# Altium schematic sheet Power - Current_Probe_V0.2.SchDoc
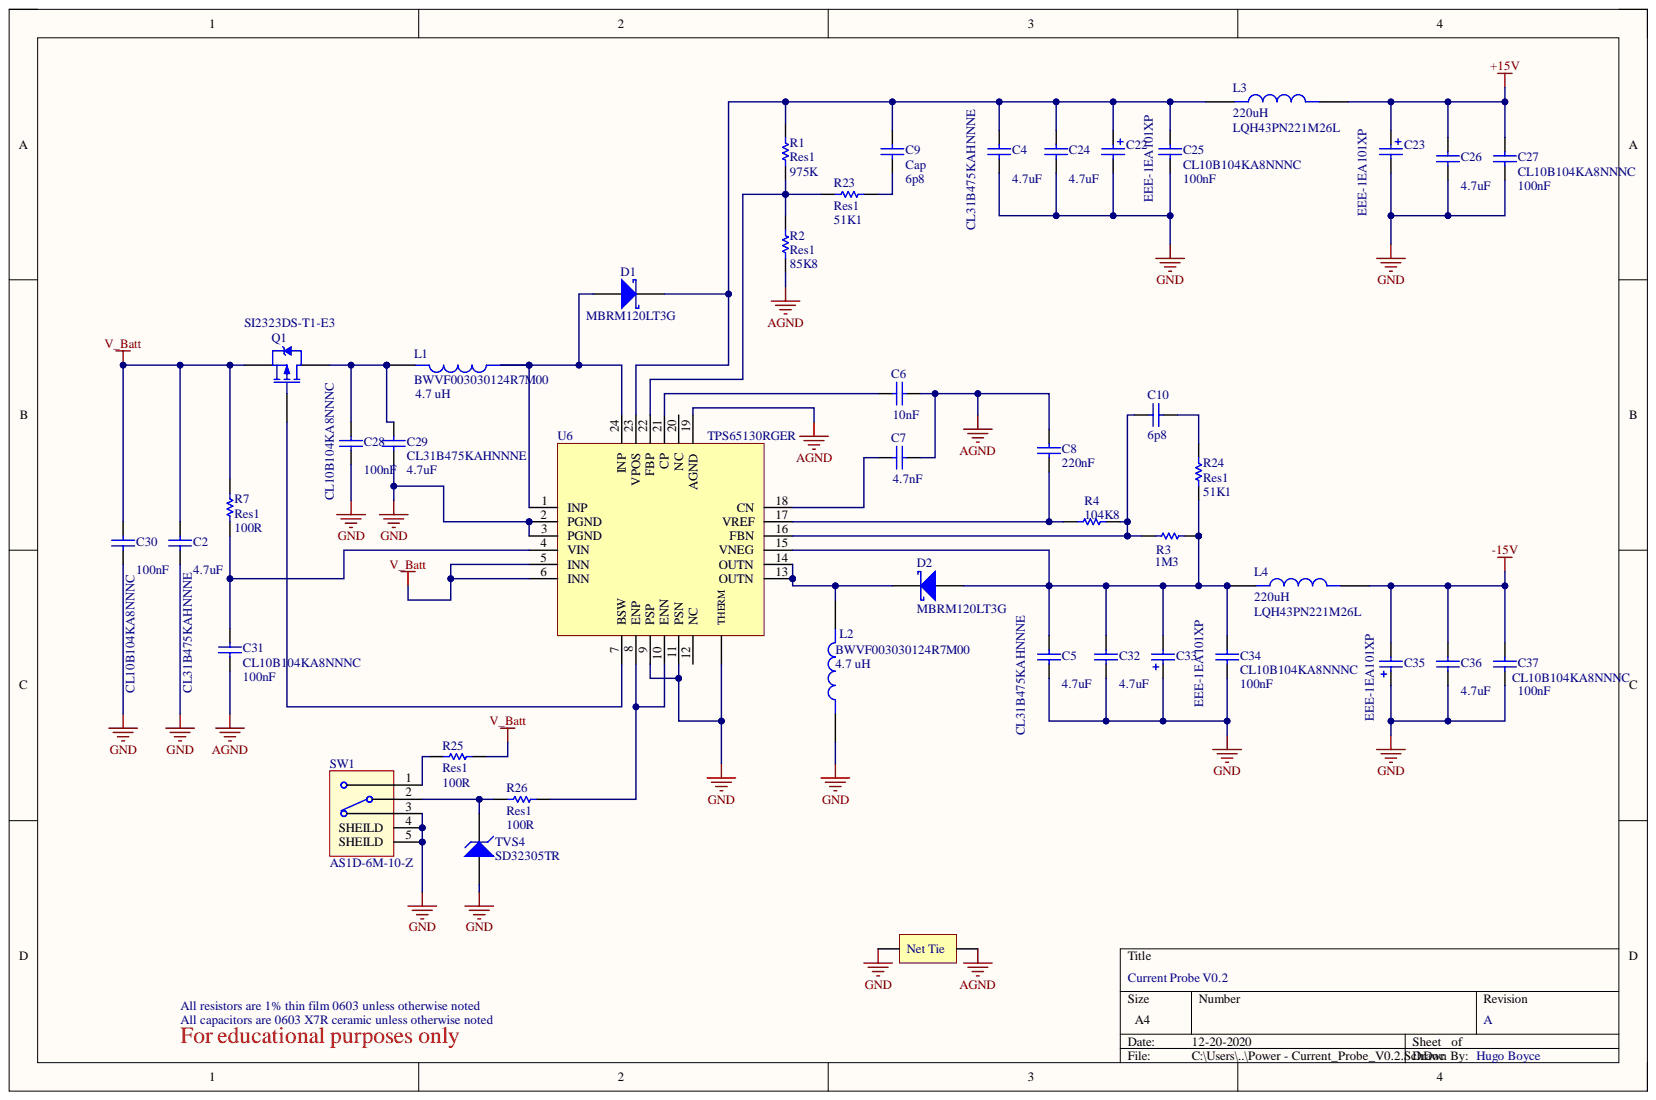
[diagram p0: Power - Current_Probe_V0.2.SchDoc]

## Parts
*  Net_Tie  [Net_Tie]
C2  CL31B475KAHNNNE  value 4.7uF  [CAPC3216N]
C4  CL31B475KAHNNNE  value 4.7uF  [CAPC3216N]
C5  CL31B475KAHNNNE  value 4.7uF  [CAPC3216N]
C6  value 10nF  [CAPC2012N]  (hidden comment: Cap)
C7  value 4.7nF  [CAPC2012N]  (hidden comment: Cap)
C8  value 220nF  [CAPC2012N]  (hidden comment: Cap)
C9  Cap  value 6p8  [CAPC2012N]
C10  value 6p8  [CAPC2012N]  (hidden comment: Cap)
C22  EEE-1EA101XP  [SMD_Electrolytic_Panasonic_Size_D8]
C23  EEE-1EA101XP  [SMD_Electrolytic_Panasonic_Size_D8]
C24  CL31B475KAHNNNE  value 4.7uF  [CAPC3216N]
C25  CL10B104KA8NNNC  value 100nF  [CAPC1608N]
C26  CL31B475KAHNNNE  value 4.7uF  [CAPC3216N]
C27  CL10B104KA8NNNC  value 100nF  [CAPC1608N]
C28  CL10B104KA8NNNC  value 100nF  [CAPC1608N]
C29  CL31B475KAHNNNE  value 4.7uF  [CAPC3216N]
C30  CL10B104KA8NNNC  value 100nF  [CAPC1608N]
C31  CL10B104KA8NNNC  value 100nF  [CAPC1608N]
C32  CL31B475KAHNNNE  value 4.7uF  [CAPC3216N]
C33  EEE-1EA101XP  [SMD_Electrolytic_Panasonic_Size_D8]
C34  CL10B104KA8NNNC  value 100nF  [CAPC1608N]
C35  EEE-1EA101XP  [SMD_Electrolytic_Panasonic_Size_D8]
C36  CL31B475KAHNNNE  value 4.7uF  [CAPC3216N]
C37  CL10B104KA8NNNC  value 100nF  [CAPC1608N]
D1  MBRM120LT3G  [DO-216AA]
D2  MBRM120LT3G  [DO-216AA]
L1  BWVF003030124R7M00  value 4.7 uH  [BWVF00303012]
L2  BWVF003030124R7M00  value 4.7 uH  [BWVF00303012]
L3  LQH43PN221M26L  value 220uH  [IND_LQH43PN221M26L]
L4  LQH43PN221M26L  value 220uH  [IND_LQH43PN221M26L]
Q1  SI2323DS-T1-E3  [SOT23-3N]
R1  Res1  value 975K  [RESC1608N]
R2  Res1  value 85K8  [RESC1608N]
R3  value 1M3  [RESC1608N]  (hidden comment: Res1)
R4  value 104K8  [RESC1608N]  (hidden comment: Res1)
R7  Res1  value 100R  [RESC1608N]
R23  Res1  value 51K1  [RESC1608N]
R24  Res1  value 51K1  [RESC1608N]
R25  Res1  value 100R  [RESC1608N]
R26  Res1  value 100R  [RESC1608N]
SW1  AS1D-6M-10-Z  [AS1D-6M-10-Z]
TVS4  SD32305TR  [SODFL260X100-2N]
U6  TPS65130RGER  [TPS65130RGER]

## Nets
+15V: C23.K C26.2 C27.2 L3.2
-15V: C35.A C36.2 C37.2 L4.2
AGND: *.2 C6.1 C7.1 C8.1 C31.1 R2.1 U6.19(AGND)
GND: *.1 C2.1 C4.1 C5.1 C22.A C23.A C24.1 C25.1 C26.1 C27.1 C28.1 C29.1 C30.1 C32.1 C33.K C34.1 C35.K C36.1 C37.1 L2.1 SW1.3 SW1.4(SHEILD) SW1.5(SHEILD) TVS4.A U6.2(PGND) U6.3(PGND) U6.9(PSP) U6.11(PSN) U6.25(THERM)
V_Batt: C2.2 C30.2 Q1.2 R7.2 R25.2 U6.5(INN) U6.6(INN)
NetC4_2: C4.2 C9.2 C22.K C24.2 C25.2 D1.1 L3.1 R1.2 U6.23(VPOS)
NetC5_2: C5.2 C32.2 C33.A C34.2 D2.2 L4.1 R3.1 R24.2 U6.15(VNEG)
NetC6_2: C6.2 U6.21(CP)
NetC7_2: C7.2 U6.18(CN)
NetC8_2: C8.2 R4.2 U6.17(VREF)
NetC9_1: C9.1 R23.1
NetC10_1: C10.1 R3.2 R4.1 U6.16(FBN)
NetC10_2: C10.2 R24.1
NetC28_2: C28.2 C29.2 L1.2 Q1.3
NetC31_2: C31.2 R7.1 U6.4(VIN)
NetD1_2: D1.2 L1.1 U6.1(INP) U6.24(INP)
NetD2_1: D2.1 L2.2 U6.13(OUTN) U6.14(OUTN)
NetQ1_1: Q1.1 U6.7(BSW)
NetR1_1: R1.1 R2.2 R23.2 U6.22(FBP)
NetR25_1: R25.1 SW1.1
NetR26_1: R26.1 U6.8(ENP) U6.10(ENN)
NetR26_2: R26.2 SW1.2 TVS4.K

Not connected: U6 12(NC) 20(NC)

## Library data of the parts
C2 C4 C5 C24 C26 C29 C32 C36 = CL31B475KAHNNNE: Cap Ceramic 4.7uF 25V X7R 10% SMD 1206 125C Embossed T/R; Manufacturer: Samsung; Capacitance: 4700000 pF; Case Size/Dimension: 1206; Component Kind: Standard; ComponentLink1Description: http://www.samsungsem.com/kr/support/product-search/mlcc/CL31B475KAHNNNE.jsp?src-supplier=Digi-Key; ComponentLink1URL: http://www.samsungsem.com/kr/support/product-search/mlcc/CL31B475KAHNNNE.jsp?src-supplier=Digi-Key; ComponentLink2Description: https://www.distrelec.biz/Web/Downloads/_e/ng/MLCC_CatalogDownload2013_ENG.pdf?mime=application%2Fpdf&src-supplier=Distrelec; ComponentLink2URL: https://www.distrelec.biz/Web/Downloads/_e/ng/MLCC_CatalogDownload2013_ENG.pdf?mime=application%2Fpdf&src-supplier=Distrelec; ComponentLink3Description: http://www1.futureelectronics.com/doc/SAMSUNG/CL10C1R5BB8NNNC.pdf?src-supplier=Future+Electronics; ComponentLink3URL: http://www1.futureelectronics.com/doc/SAMSUNG/CL10C1R5BB8NNNC.pdf?src-supplier=Future+Electronics; ComponentLink4Description: http://www.produktinfo.conrad.com/datenblaetter/450000-474999/452772-da-01-en-VS_KONDENSATOR_1206.pdf?src-supplier=Conrad; ComponentLink4URL: http://www.produktinfo.conrad.com/datenblaetter/450000-474999/452772-da-01-en-VS_KONDENSATOR_1206.pdf?src-supplier=Conrad; ComponentLink5Description: http://www.produktinfo.conrad.com/datenblaetter/450000-474999/452785-da-01-en-VS_KONDENSATOR_1206.pdf?src-supplier=Conrad; ComponentLink5URL: http://www.produktinfo.conrad.com/datenblaetter/450000-474999/452785-da-01-en-VS_KONDENSATOR_1206.pdf?src-supplier=Conrad; Dielectric: X7R; Manufacturer Part Number: CL31B475KAHNNNE; Pricing 1: 1=0.22, 10=0.152, 50=0.0912, 100=0.077, 500=0.0527, 1000=0.0466 (USD); Rated Voltage: 25 V; Stock 1: 137774; Supplier 1: Digi-Key; Supplier Part Number 1: 1276-1055-1-ND; Tolerance (%): ±10 %; Library Name: Current_Probe_Library.SchLib
C6-C10 = Cap: Capacitor; Component Kind: Standard; LatestRevisionDate: 17-Jul-2002; LatestRevisionNote: Re-released for DXP Platform.; Library Name: Miscellaneous Devices.SchLib; PackageDescription: Radial Cap, Thru-Hole; 2 Leads; 0.3 in Pin Spacing; PackageReference: RAD-0.3; Published: 8-Jun-2000; Signal Integrity: Cap; Simulation: CAP; Publisher: Altium Limited
C22 C23 C33 C35 = EEE-1EA101XP: Cap Aluminum Lytic 100uF 25V 20% (6.3 X 7.7mm) SMD 143mA 2000h 85C T/R; Manufacturer: Panasonic; Capacitance: 100µF; Catalog Drawings: VS Series Bottom_D,D8 VS Series Side_D8; EDA / CAD Models: Download from Accelerated Designs; Lifetime @ Temp.: 2000 Hrs @ 85°C; Online Catalog: VS Series; Other Names: EEE1EA101XP   PCE3898TR; Polarization: Polar; Product Training Modules: LED Lighting Components; Ripple Current: 143mA @ 120Hz; Surface Mount Land Size: 0.260" L x 0.260" W (6.60mm x 6.60mm); Applications: Automotive; Category: Capacitors; Component Kind: Standard; ComponentLink1Description: https://industrial.panasonic.com/cdbs/www-data/pdf/RDE0000/DME0000COL90.pdf?src-supplier=Avnet+Europe; ComponentLink1URL: https://industrial.panasonic.com/cdbs/www-data/pdf/RDE0000/DME0000COL90.pdf?src-supplier=Avnet+Europe; ComponentLink2Description: https://industrial.panasonic.com/cdbs/www-data/pdf/RDE0000/ABA0000C1145.pdf?src-supplier=Future+Electronics; ComponentLink2URL: https://industrial.panasonic.com/cdbs/www-data/pdf/RDE0000/ABA0000C1145.pdf?src-supplier=Future+Electronics; ComponentLink3Description: https://industrial.panasonic.com/cdbs/www-data/pdf/RDE0000/DME0000COL90.pdf?src-supplier=Mouser; ComponentLink3URL: https://industrial.panasonic.com/cdbs/www-data/pdf/RDE0000/DME0000COL90.pdf?src-supplier=Mouser; Family: Aluminum Capacitors; Height - Seated (Max): 0.303" (7.70mm); Manufacturer Part Number: EEE-1EA101XP; Mounting Type: Surface Mount; Operating Temperature: -40°C ~ 85°C; Package / Case: Radial, Can - SMD; Packaging: Tape & Reel (TR); Pricing 1: 1=0.45, 10=0.314, 50=0.2194, 100=0.1881 (USD); Series: Automotive, AEC-Q200, S; Size / Dimension: 0.248" Dia (6.30mm); Standard Package: 900; Stock 1: 124851; Supplier 1: Digi-Key; Supplier Part Number 1: PCE3898CT-ND; Tolerance: ±20%; Voltage Rating: 25V; Library Name: Current_Probe_Library.SchLib
C25 C27 C28 C30 C31 C34 C37 = CL10B104KA8NNNC: CAP CER 0.1UF 25V X7R 0603; Manufacturer: Samsung; Capacitance: 100000 pF; Tolerance (%): ±10 %; Case Size/Dimension: 0603; Component Kind: Standard; ComponentLink1Description: http://www.samsungsem.com/kr/support/product-search/mlcc/__icsFiles/afieldfile/2018/08/29/Data%20sheet_CL10B104KA8NNNC.pdf?src-supplier=Digi-Key; ComponentLink1URL: http://www.samsungsem.com/kr/support/product-search/mlcc/__icsFiles/afieldfile/2018/08/29/Data%20sheet_CL10B104KA8NNNC.pdf?src-supplier=Digi-Key; ComponentLink2Description: https://www.verical.com/server-webapp/html/getDatasheet?partID=354050&src-supplier=Verical; ComponentLink2URL: https://www.verical.com/server-webapp/html/getDatasheet?partID=354050&src-supplier=Verical; Dielectric: X7R; Manufacturer Part Number: CL10B104KA8NNNC; Pricing 1: 1=0.1, 10=0.029, 50=0.016, 100=0.013, 500=0.0093, 1000=0.0073 (USD); Rated Voltage: 25 V; Stock 1: 4133737; Supplier 1: Digi-Key; Supplier Part Number 1: 1276-1006-1-ND; Library Name: Current_Probe_Library.SchLib
D1 D2 = MBRM120LT3G: Diode Schottky 20V 1A 2-Pin(1+Tab) Power Mite T/R; Manufacturer: ON Semiconductor; Diode Configuration: Single; Forward Surge Current Ifsm Max: 50A; Forward Voltage VF Max: 450mV; No. of Pins: 2Pins; SVHC: No SVHC (15-Jun-2015); Component Kind: Standard; ComponentLink1Description: http://uk.rs-online.com/webdocs/1221/0900766b812212db.pdf?src-supplier=RSComponents; ComponentLink1URL: http://uk.rs-online.com/webdocs/1221/0900766b812212db.pdf?src-supplier=RSComponents; ComponentLink2Description: http://www.farnell.com/datasheets/1571874.pdf?src-supplier=Element14; ComponentLink2URL: http://www.farnell.com/datasheets/1571874.pdf?src-supplier=Element14; ComponentLink3Description: http://www.onsemi.com/pub/Collateral/MBRM120L-D.PDF?src-supplier=Digi-Key; ComponentLink3URL: http://www.onsemi.com/pub/Collateral/MBRM120L-D.PDF?src-supplier=Digi-Key; ComponentLink4Description: http://www.onsemi.com/pub/Collateral/MBRM120L-D.PDF?src-supplier=Avnet; ComponentLink4URL: http://www.onsemi.com/pub/Collateral/MBRM120L-D.PDF?src-supplier=Avnet; ComponentLink5Description: http://www.mouser.com/ds/2/308/MBRM120L-D-76548.pdf?src-supplier=Mouser; ComponentLink5URL: http://www.mouser.com/ds/2/308/MBRM120L-D-76548.pdf?src-supplier=Mouser; ComponentLink6Description: http://www.onsemi.cn/pub/Collateral/MBRM120L-D.PDF?src-supplier=Avnet+Europe; ComponentLink6URL: http://www.onsemi.cn/pub/Collateral/MBRM120L-D.PDF?src-supplier=Avnet+Europe; Diode Case Style: DO-216AA; Diode Type: Schottky; Forward Current If(AV): 1A; Manufacturer Part Number: MBRM120LT3G; Operating Temperature Max: 125°C; Packaging: Cut Tape; Pricing 1: 1=0.36, 10=0.292, 25=0.2676, 100=0.1679, 500=0.1555, 1000=0.1057, 2500=0.1014, 5000=0.0933 (USD); Product Range: -; Repetitive Reverse Voltage Vrrm Max: 20V; Stock 1: 16398; Supplier 1: Digi-Key; Supplier Part Number 1: MBRM120LT3GOSCT-ND; Library Name: Current_Probe_Library.SchLib
L1 L2 = BWVF003030124R7M00: Ind. Pwr Ferrite Inductor Ww; Manufacturer: Chilisin; Component Kind: Standard; Manufacturer Part Number: BWVF003030124R7M00; Pricing 1: 1=0.27, 10=0.237, 25=0.2076, 50=0.178, 100=0.1632, 250=0.1484, 500=0.1395, 1000=0.1068 (USD); Stock 1: 4000; Supplier 1: Digi-Key; Supplier Part Number 1: 2184-BWVF003030124R7M00CT-ND; Library Name: Current_Probe_Library.SchLib
L3 L4 = LQH43PN221M26L: Inductor with Inductance: 220uH Tol. +/-20%, Package: 1812 _4532_; MF: Murata; Component Kind: Standard; Digi-Key_Part_Number: 490-13387-1-ND; Digi-Key_Purchase_URL: https://www.digikey.com/product-detail/en/murata-electronics/LQH43PN221M26L/490-13387-1-ND/5973379?utm_source=snapeda&utm_medium=aggregator&utm_campaign=symbol; MP: LQH43PN221M26L; Package: NONSTANDARD; SnapEDA_Link: https://www.snapeda.com/parts/LQH43PN221M26L/Murata/view-part/1503095/?ref=snap; Library Name: Current_Probe_Library.SchLib
Q1 = SI2323DS-T1-E3: MOSFET P-CH 20V 3.7A SOT23-3; Manufacturer: Vishay; Part # Aliases: SI2323DS-E3; Brand: Vishay Semiconductors; Channel Mode: Enhancement; Configuration: Single; Fall Time: 43 ns; Number of Channels: 1 Channel; Pd - Power Dissipation: 750 mW; Rds On - Drain-Source Resistance: 39 mOhms; Transistor Polarity: P-Channel; Transistor Type: 1 P-Channel; Typical Turn-Off Delay Time: 71 ns; Typical Turn-On Delay Time: 25 ns; Unit Weight: 0.050717 oz; Vds - Drain-Source Breakdown Voltage: - 20 V; Vgs - Gate-Source Voltage: 8 V; Component Kind: Standard; ComponentLink1Description: http://www.vishay.com/docs/72024/72024.pdf?src-supplier=Future+Electronics; ComponentLink1URL: http://www.vishay.com/docs/72024/72024.pdf?src-supplier=Future+Electronics; ComponentLink2Description: https://www.verical.com/server-webapp/html/getDatasheet?partID=75162&src-supplier=Verical; ComponentLink2URL: https://www.verical.com/server-webapp/html/getDatasheet?partID=75162&src-supplier=Verical; ComponentLink3Description: http://www.vishay.com/docs/72024/72024.pdf?src-supplier=Avnet; ComponentLink3URL: http://www.vishay.com/docs/72024/72024.pdf?src-supplier=Avnet; ComponentLink4Description: http://www.farnell.com/datasheets/2049166.pdf?src-supplier=Element14; ComponentLink4URL: http://www.farnell.com/datasheets/2049166.pdf?src-supplier=Element14; ComponentLink5Description: http://www.vishay.com/docs/72024/72024.pdf?src-supplier=Avnet+Europe; ComponentLink5URL: http://www.vishay.com/docs/72024/72024.pdf?src-supplier=Avnet+Europe; ComponentLink6Description: http://www.vishay.com/docs/72024/72024.pdf?src-supplier=Digi-Key; ComponentLink6URL: http://www.vishay.com/docs/72024/72024.pdf?src-supplier=Digi-Key; ComponentLink7Description: http://datasheet.iiic.cc/vishay_[siliconix]/SI2323DS-T1-E3.pdf?src-supplier=IIIC; ComponentLink7URL: http://datasheet.iiic.cc/vishay_[siliconix]/SI2323DS-T1-E3.pdf?src-supplier=IIIC; Factory Pack Quantity: 3000; Height: 1.02 mm; Id - Continuous Drain Current: 3.7 A; Length: 3.04 mm; Manufacturer Part Number: SI2323DS-T1-E3; Maximum Operating Temperature: + 150 C; Minimum Operating Temperature: - 55 C; Mounting Style: SMD/SMT; Package / Case: SOT-23-3; Packaging: Reel; Pricing 1: 1=0.64, 10=0.55, 25=0.5136, 100=0.3814, 500=0.2978, 1000=0.2421 (USD); Product: MOSFET Small Signal; Product Category: MOSFET; Rise Time: 43 ns; RoHS: True; Series: SI2; Stock 1: 35228; Supplier 1: Digi-Key; Supplier Part Number 1: SI2323DS-T1-E3CT-ND; Technology: Si; Tradename: TrenchFET; Width: 1.4 mm; Library Name: Current_Probe_Library.SchLib
R1-R4 R7 R23-R25 = Res1: Resistor; Component Kind: Standard; LatestRevisionDate: 17-Jul-2002; LatestRevisionNote: Re-released for DXP Platform.; Library Name: Miscellaneous Devices.SchLib; PackageDescription: Axial Device, Thru-Hole; 2 Leads; 0.3 in Pin Spacing; PackageReference: AXIAL-0.3; Published: 8-Jun-2000; Signal Integrity: Res; Simulation: RESISTOR; Publisher: Altium Limited
R26 = Res1: Resistor; Value: 100R; Component Kind: Standard; LatestRevisionDate: 17-Jul-2002; LatestRevisionNote: Re-released for DXP Platform.; Library Name: Miscellaneous Devices.SchLib; PackageDescription: Axial Device, Thru-Hole; 2 Leads; 0.3 in Pin Spacing; PackageReference: AXIAL-0.3; Published: 8-Jun-2000; Signal Integrity: Res; Simulation: RESISTOR; Publisher: Altium Limited
SW1 = AS1D-6M-10-Z: Switch Slide SPDT 50MA 48V; Manufacturer: Nidec Copal; Actuator Length: 4.30mm; Actuator Type: Standard; Contact Timing: Non-Shorting (BBM); Current Rating: 50mA (AC/DC); Electrical Life: 10,000 Cycles; Operating Force: 70 ~ 500gf; Switch Function: On-On; Switch Travel: 2.20mm; Actuator Material: -; Category: Switches; Circuit: SPDT; Component Kind: Standard; ComponentLink1Description: https://www.nidec-copal-electronics.com/e/catalog/switch/as.pdf?src-supplier=Digi-Key; ComponentLink1URL: https://www.nidec-copal-electronics.com/e/catalog/switch/as.pdf?src-supplier=Digi-Key; Contact Finish: Gold; Contact Material: -; Family: Slide Switches; Features: -; Manufacturer Part Number: AS1D-6M-10-Z; Mechanical Life: -; Mounting Type: Through Hole, Right Angle; Operating Temperature: -20°C ~ 85°C; Packaging: Tray; Pricing 1: 1=2.47, 10=2.405, 25=2.1876, 100=1.9004, 250=1.7222, 500=1.6035, 1000=1.4847, 2500=1.3461, 5000=1.2472 (USD); Series: AS; Stock 1: 269; Supplier 1: Digi-Key; Supplier Part Number 1: 563-1581-ND; Termination Style: PC Pin; Voltage Rating - AC: 48V; Voltage Rating - DC: 48V; Library Name: Current_Probe_Library.SchLib
TVS4 = SD32305TR: TVS DIODE 5V 9.8V SOD323; Manufacturer: SMC; Capacitance @ Frequency: 600pF @ 1MHz; Categories: Circuit Protection; Current - Peak Pulse (10/1000µs): 1A (8/20µs); Power - Peak Pulse: 300W; Power Line Protection: No; Unidirectional Channels: 1; Voltage - Breakdown (Min): 6V; Voltage - Reverse Standoff (Typ): 5V (Max); Applications: General Purpose; Component Kind: Standard; ComponentLink1Description: http://www.smc-diodes.com/propdf/SD32303%20THRU%20SD32324%20N0428%20REV.B.pdf?src-supplier=Digi-Key; ComponentLink1URL: http://www.smc-diodes.com/propdf/SD32303%20THRU%20SD32324%20N0428%20REV.B.pdf?src-supplier=Digi-Key; Manufacturer Part Number: SD32305TR; Mounting Type: Surface Mount; Operating Temperature: -55°C ~ 125°C (TJ); Package / Case: SC-76, SOD-323; Packaging: Digi-Reel®; Part Status: Active; Pricing 1: 1=0.22, 10=0.179, 25=0.1496, 100=0.0732, 500=0.061, 1000=0.0424 (USD); Series: -; Stock 1: 23873; Supplier 1: Digi-Key; Supplier Device Package: SOD-323; Supplier Part Number 1: 1655-1528-1-ND; Type: Zener; Voltage - Clamping (Max) @ Ipp: 9.8V; Library Name: Current_Probe_Library.SchLib
U6 = TPS65130RGER: IC DC-DC CONVERTER Pos & Neg Rail; Manufacturer: Texas Instruments; Efficiency: 85 %; Maximum Input Voltage: 5.5 V; Maximum Output Current: 0.8(Typ) A; Minimum Input Voltage: 2.7 V; Number of Outputs: 2; Operating Supply Voltage: 2.7 to 5.5 V; Output Voltage: -15 to -2|3.2 to 15 V; Rad Hard: No; Switching Frequency: 1380(Typ) kHz; Category: DC to DC Converter; Component Kind: Standard; ComponentLink1Description: http://www.ti.com/lit/gpn/tps65130?src-supplier=Mouser; ComponentLink1URL: http://www.ti.com/lit/gpn/tps65130?src-supplier=Mouser; ComponentLink2Description: https://www.verical.com/server-webapp/html/getDatasheet?partID=48122&src-supplier=Verical; ComponentLink2URL: https://www.verical.com/server-webapp/html/getDatasheet?partID=48122&src-supplier=Verical; ComponentLink3Description: http://focus.ti.com/lit/ds/symlink/tps65130.pdf?src-supplier=Avnet; ComponentLink3URL: http://focus.ti.com/lit/ds/symlink/tps65130.pdf?src-supplier=Avnet; ComponentLink4Description: http://www.ti.com/lit/ds/symlink/tps65130.pdf?src-supplier=Digi-Key; ComponentLink4URL: http://www.ti.com/lit/ds/symlink/tps65130.pdf?src-supplier=Digi-Key; ComponentLink5Description: http://www.ti.com/general/docs/lit/getliterature.tsp?genericPartNumber=TPS65131&&fileType=pdf&src-supplier=Avnet; ComponentLink5URL: http://www.ti.com/general/docs/lit/getliterature.tsp?genericPartNumber=TPS65131&&fileType=pdf&src-supplier=Avnet; ComponentLink6Description: http://www.farnell.com/datasheets/1861031.pdf?src-supplier=Newark; ComponentLink6URL: http://www.farnell.com/datasheets/1861031.pdf?src-supplier=Newark; ComponentLink7Description: http://www.farnell.com/datasheets/2018909.pdf?src-supplier=Element14; ComponentLink7URL: http://www.farnell.com/datasheets/2018909.pdf?src-supplier=Element14; ComponentLink8Description: http://datasheet.iiic.cc/texas_instruments/TPS65130RGER.pdf?src-supplier=IIIC; ComponentLink8URL: http://datasheet.iiic.cc/texas_instruments/TPS65130RGER.pdf?src-supplier=IIIC; Manufacturer Part Number: TPS65130RGER; Mounting: Surface Mount; Operating Temperature: -40 to 85 °C; Package: 24VQFN EP; Packaging: Tape & Reel; Pricing 1: 1=2.86, 10=2.565, 25=2.42, 100=1.936, 250=1.694, 500=1.6456, 1000=1.3068 (USD); Stock 1: 3410; Supplier 1: Digi-Key; Supplier Part Number 1: 296-17080-1-ND; Type: Inverting|Step Up; Library Name: Current_Probe_Library.SchLib
* = Net_Tie: Component Kind: Net Tie; Library Name: Current_Probe_Library.SchLib
